# Altium schematic sheet sch_power.SchDoc
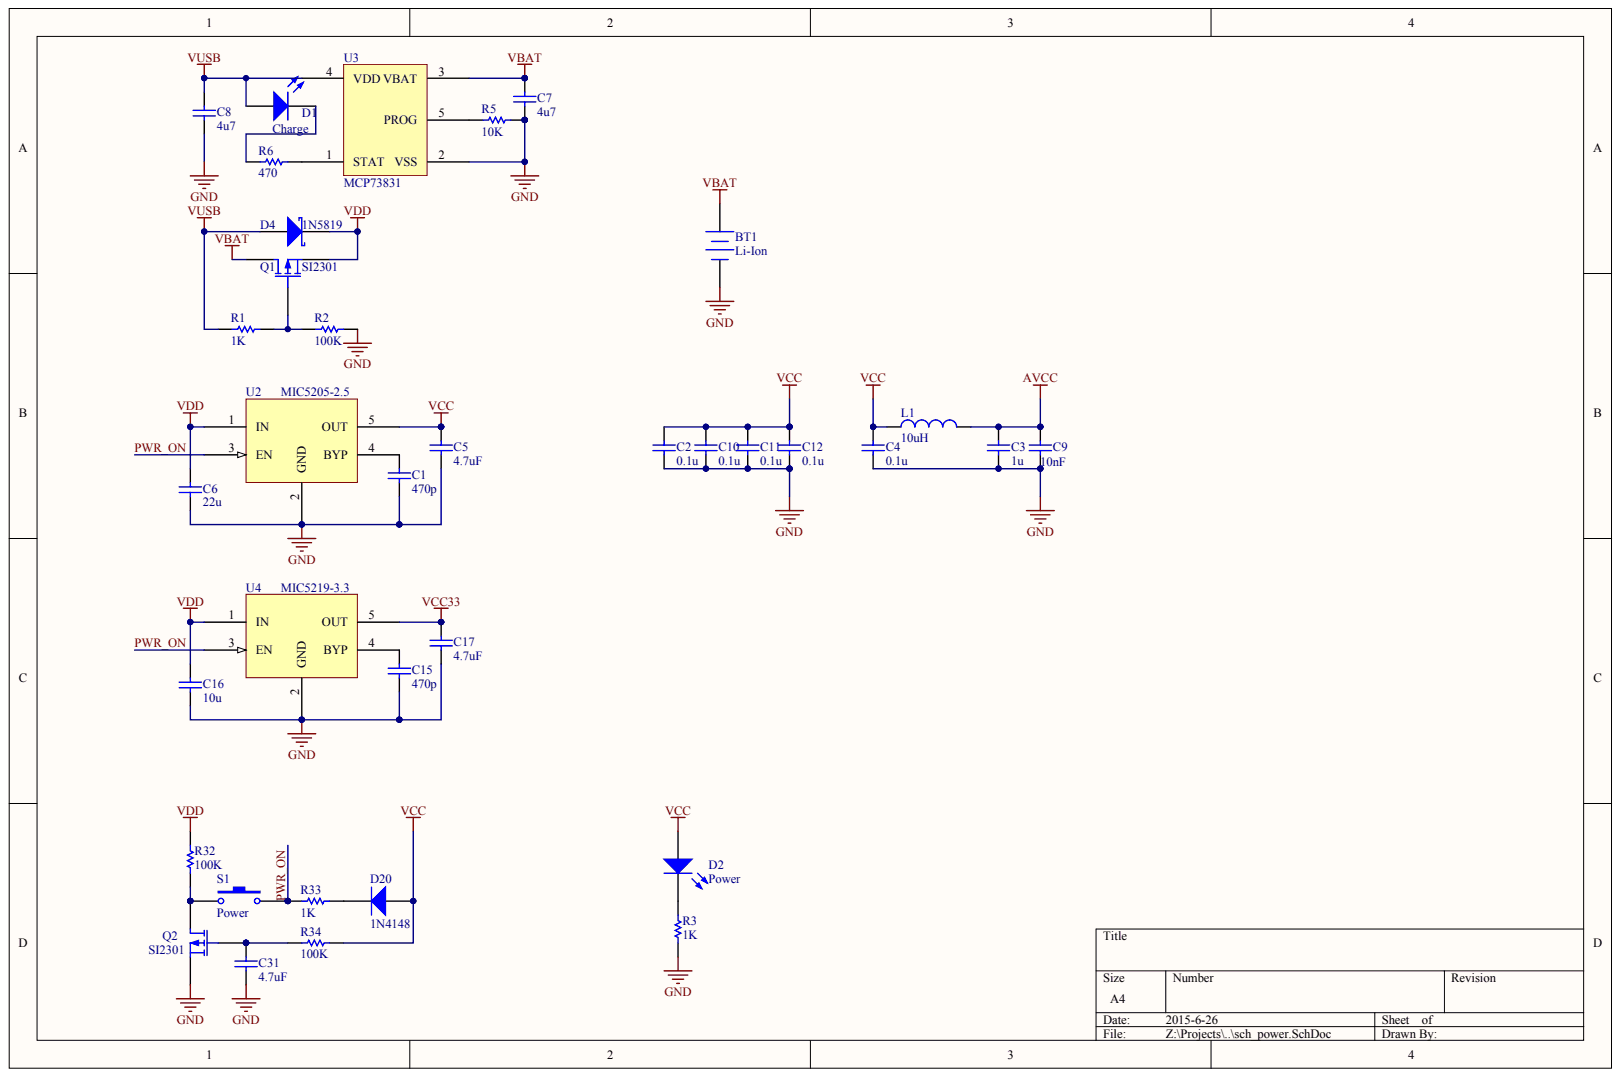
[diagram p0: sch_power.SchDoc]

## Parts
BT1  Li-Ion
C1  value 470p  (hidden comment: Cap Semi)
C2  value 0.1u  (hidden comment: Cap Semi)
C3  value 1u  (hidden comment: Cap Semi)
C4  value 0.1u  (hidden comment: Cap Semi)
C5  value 4.7uF  (hidden comment: Cap Semi)
C6  value 22u  (hidden comment: Cap Semi)
C7  value 4u7  (hidden comment: Cap Semi)
C8  value 4u7  (hidden comment: Cap Semi)
C9  value 10nF  (hidden comment: Cap Semi)
C10  value 0.1u  (hidden comment: Cap Semi)
C11  value 0.1u  (hidden comment: Cap Semi)
C12  value 0.1u  (hidden comment: Cap Semi)
C15  value 470p  (hidden comment: Cap Semi)
C16  value 10u  (hidden comment: Cap Semi)
C17  value 4.7uF  (hidden comment: Cap Semi)
C31  value 4.7uF  (hidden comment: Cap Semi)
D1  Charge
D2  Power
D4  1N5819
D20  1N4148
L1  value 10uH  (hidden comment: Inductor)
Q1  SI2301
Q2  SI2301
R1  value 1K  (hidden comment: Res Semi)
R2  value 100K  (hidden comment: Res Semi)
R3  value 1K  (hidden comment: Res Semi)
R5  value 10K  (hidden comment: Res Semi)
R6  value 470  (hidden comment: Res Semi)
R32  value 100K  (hidden comment: Res Semi)
R33  value 1K  (hidden comment: Res Semi)
R34  value 100K  (hidden comment: Res Semi)
S1  Power
U2  MIC5205-2.5
U3  MCP73831
U4  MIC5219-3.3

## Nets
AVCC: C3.2 C9.2 L1.2
GND: BT1.2 C1.2 C2.1 C3.1 C4.1 C5.2 C6.2 C7.1 C8.1 C9.1 C10.1 C11.1 C12.1 C15.2 C16.2 C17.2 C31.1 Q2.3 R2.2 R3.2 R5.1 U2.2(GND) U3.2(VSS) U4.2(GND)
VBAT: BT1.1 C7.2 Q1.1 U3.3(VBAT)
VCC: C2.2 C4.2 C5.1 C10.2 C11.2 C12.2 D2.1 D20.1 L1.1 R34.2 U2.5(OUT)
VCC33: C17.1 U4.5(OUT)
VDD: C6.1 C16.1 D4.2 Q1.3 R32.2 U2.1(IN) U4.1(IN)
VUSB: C8.2 D1.1 D4.1 R1.1 U3.4(VDD)
PWR_ON: R33.2 S1.2 U2.3(EN) U4.3(EN)
NetC1_1: C1.1 U2.4(BYP)
NetC15_1: C15.1 U4.4(BYP)
NetC31_2: C31.2 Q2.2 R34.1
NetD1_2: D1.2 R6.1
NetD2_2: D2.2 R3.1
NetD20_2: D20.2 R33.1
NetQ1_2: Q1.2 R1.2 R2.1
NetQ2_1: Q2.1 R32.1 S1.1
NetR5_2: R5.2 U3.5(PROG)
NetR6_2: R6.2 U3.1(STAT)

## Library data of the parts
L1 = (no symbol name): Code_IEC: 1005; Published: 8-Jun-2000; LatestRevisionDate: 17-Jul-2002; LatestRevisionNote: Re-released for DXP Platform.; PackageReference: 0402-A; Code_JEITA: 0402; Publisher: Altium Limited
Q1 Q2 = (no symbol name): PackageDocument: 1995/1996; Published: 8-Jun-2000; LatestRevisionDate: 17-Jul-2002; LatestRevisionNote: Re-released for DXP Platform.; PackageReference: E3; Publisher: Altium Limited
BT1 C1-C12 C15-C17 C31 D1 D2 D4 D20 R1-R3 R5 R6 R32-R34 S1 U2-U4 = (no symbol name)
  BT1: Published: 24-Mar-1999; LatestRevisionDate: 17-Jul-2002; LatestRevisionNote: Re-released for DXP Platform.; PackageReference: BAT-2
  C1 C5 C6 C15-C17: Published: 8-Jun-2000; LatestRevisionDate: 29-May-2009; LatestRevisionNote: IPC-7351 Footprint Added.; PackageReference: C1206; PackageDescription: Chip Capacitor
  C2-C4 C7-C12 C31: Published: 8-Jun-2000; LatestRevisionDate: 17-Jul-2002; LatestRevisionNote: Re-released for DXP Platform.; PackageReference: C1206
  D1 D2: Published: 8-Jun-2000; LatestRevisionDate: 17-Jul-2002; LatestRevisionNote: Re-released for DXP Platform.; PackageDocument: Feb-2002; PackageReference: 3.2X1.6X1.1
  D4: Published: 8-Jun-2000; LatestRevisionDate: 17-Jul-2002; LatestRevisionNote: Re-released for DXP Platform.
  D20: Published: 8-Jun-2000; LatestRevisionDate: 17-Jul-2002; LatestRevisionNote: Re-released for DXP Platform.; DatasheetDocument: 1997; PackageReference: DO-35; Code_JEDEC: DO-204-AH; ComponentLink1Description: Manufacturer Link; ComponentLink1URL: http://www.fairchildsemi.com/; ComponentLink2Description: Datasheet; ComponentLink2URL: http://www.fairchildsemi.com/ds/1N%2F1N4148.pdf
  R1 R2 R5 R6: Published: 8-Jun-2000; LatestRevisionDate: 17-Jul-2002; LatestRevisionNote: Re-released for DXP Platform.; PackageReference: AXIAL-0.5
  R3 R32-R34: Published: 8-Jun-2000; LatestRevisionDate: 17-Jul-2002; LatestRevisionNote: Re-released for DXP Platform.; PackageReference: AXIAL-0.5; PackageDescription: Axial Device, Thru-Hole; 2 Leads; 0.5 in Pin Spacing
  S1: Published: 24-Mar-1999; LatestRevisionDate: 17-Jul-2002; LatestRevisionNote: Re-released for DXP Platform.; PackageReference: SPST-2
  BT1 C1-C12 C15-C17 C31 D1 D2 D4 D20 R1-R3 R5 R6 R32-R34 S1: Publisher: Altium Limited
